# Altium schematic sheet D1_CORE_INTERFACE.SchDoc(D1_CORE_INTERFACE)
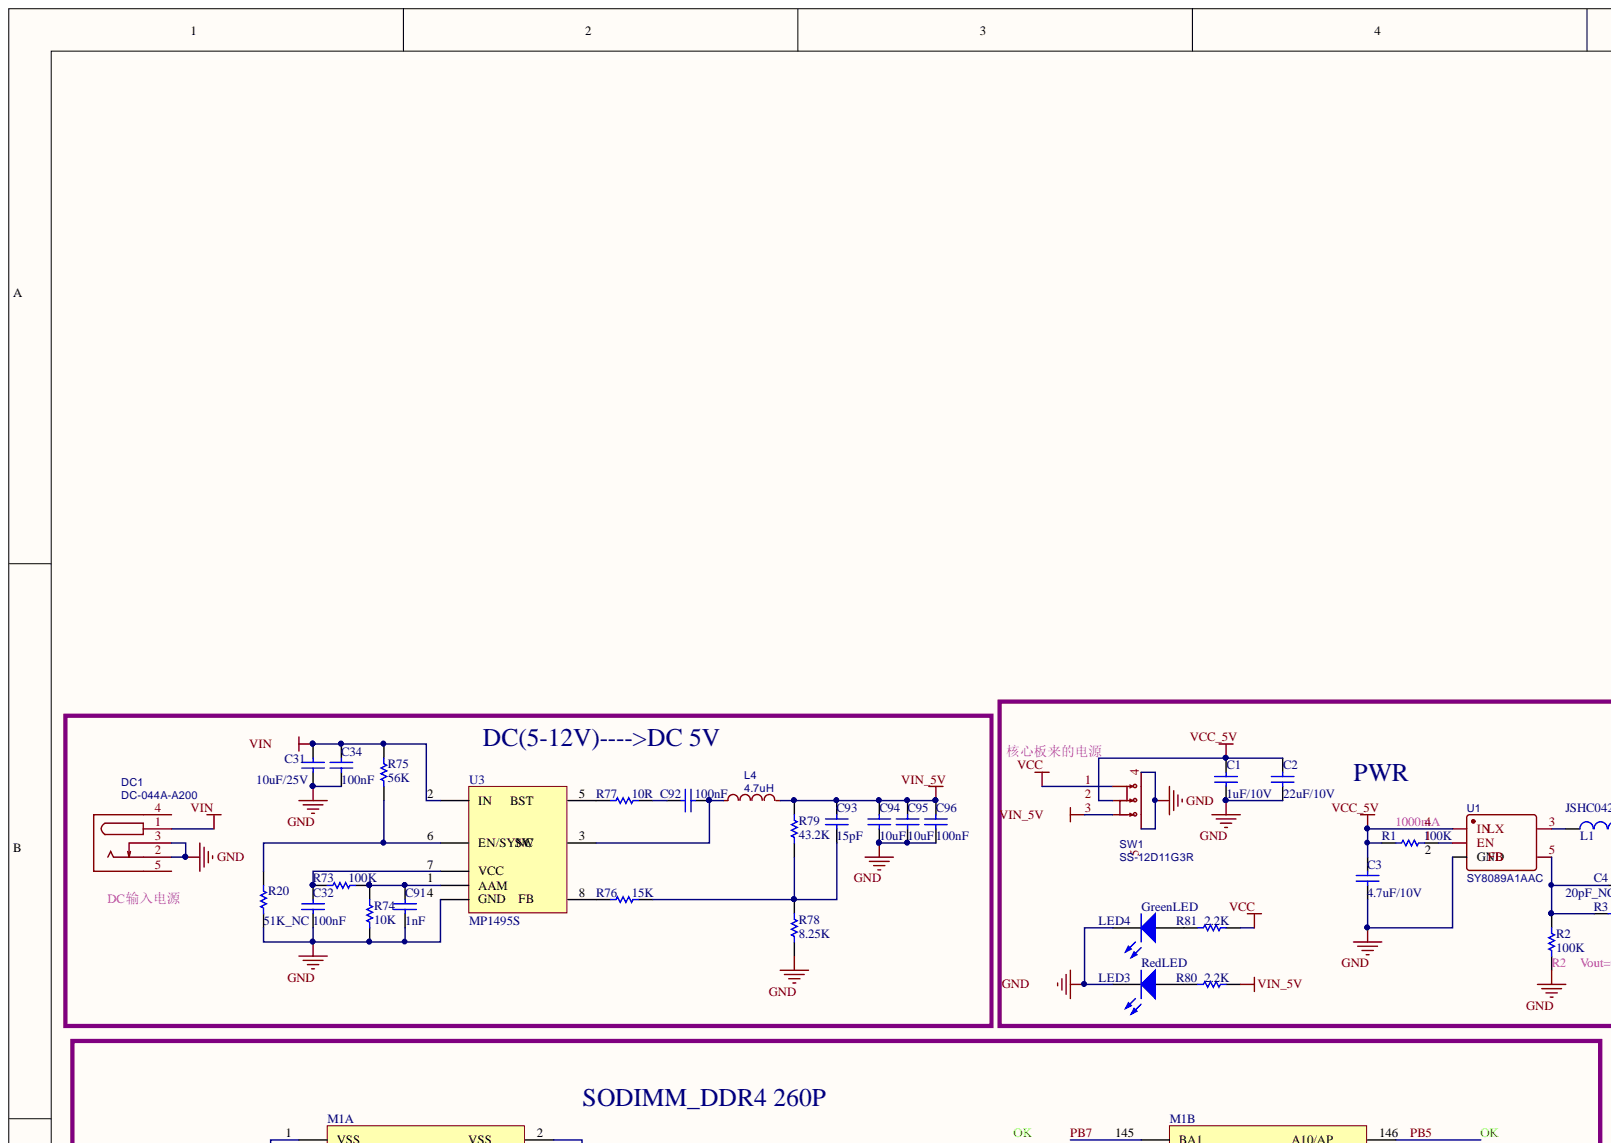
[diagram p0: D1_CORE_INTERFACE.SchDoc, top left]
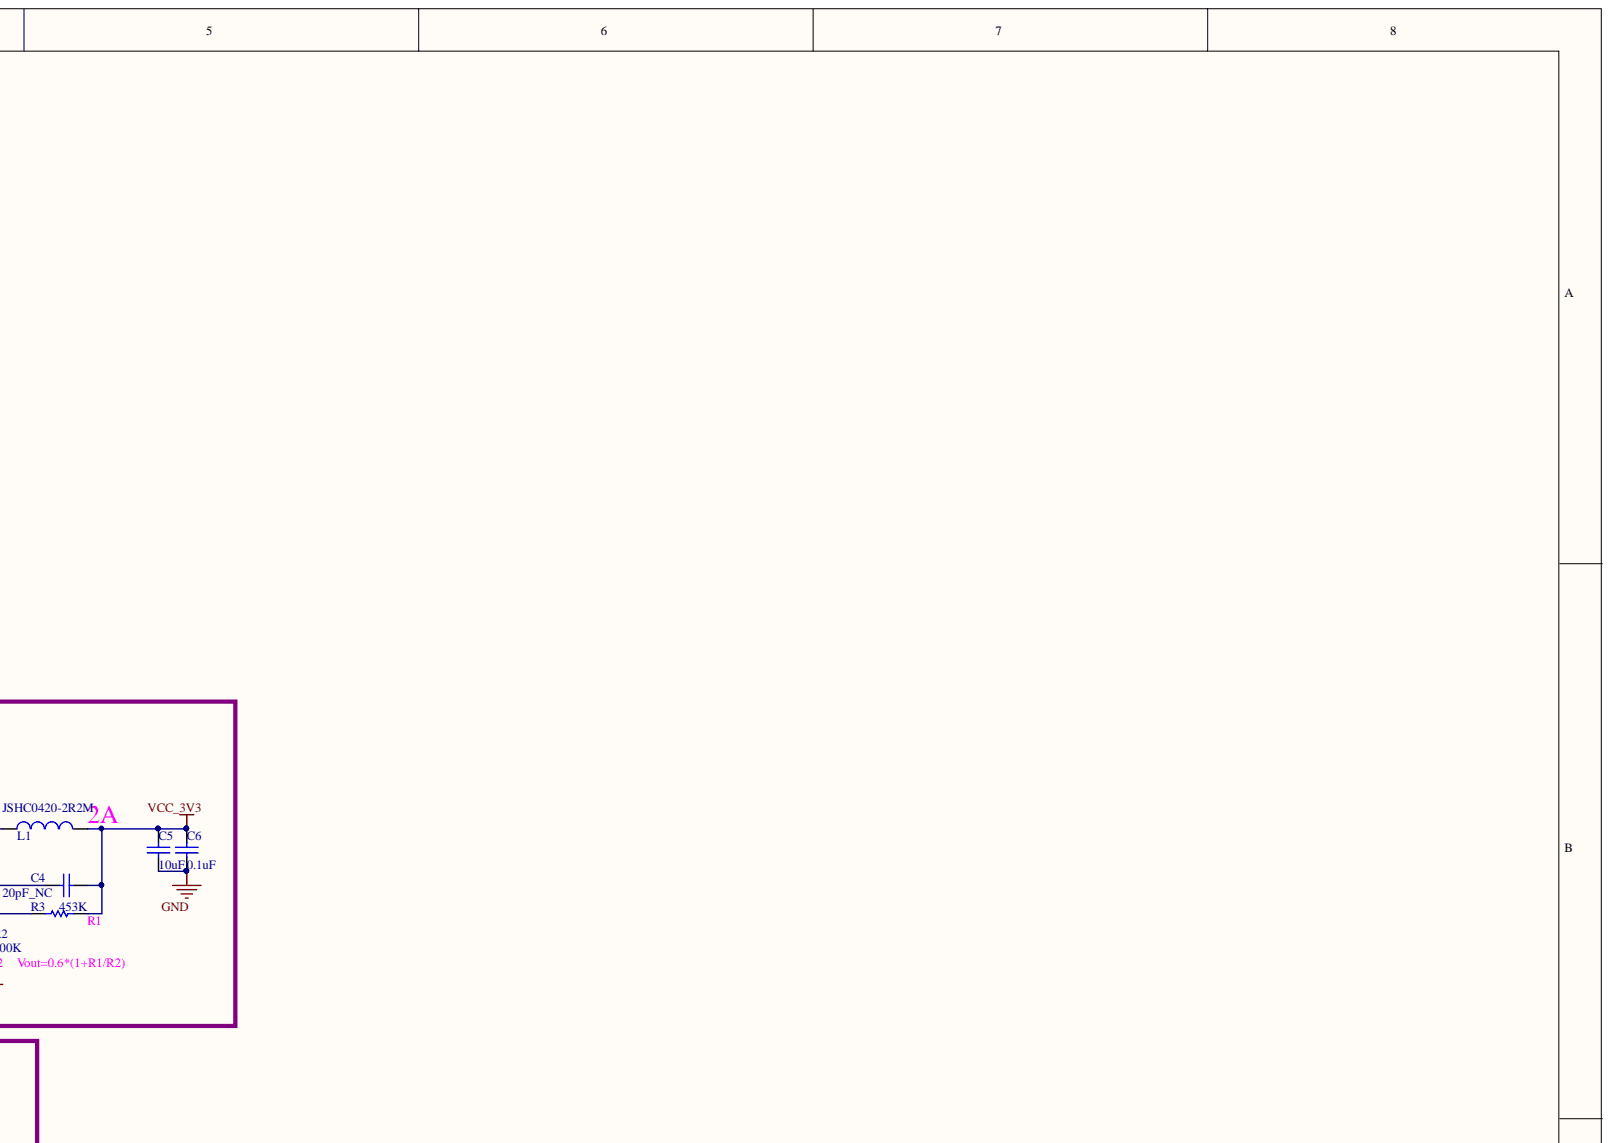
[diagram p1: D1_CORE_INTERFACE.SchDoc, top right]
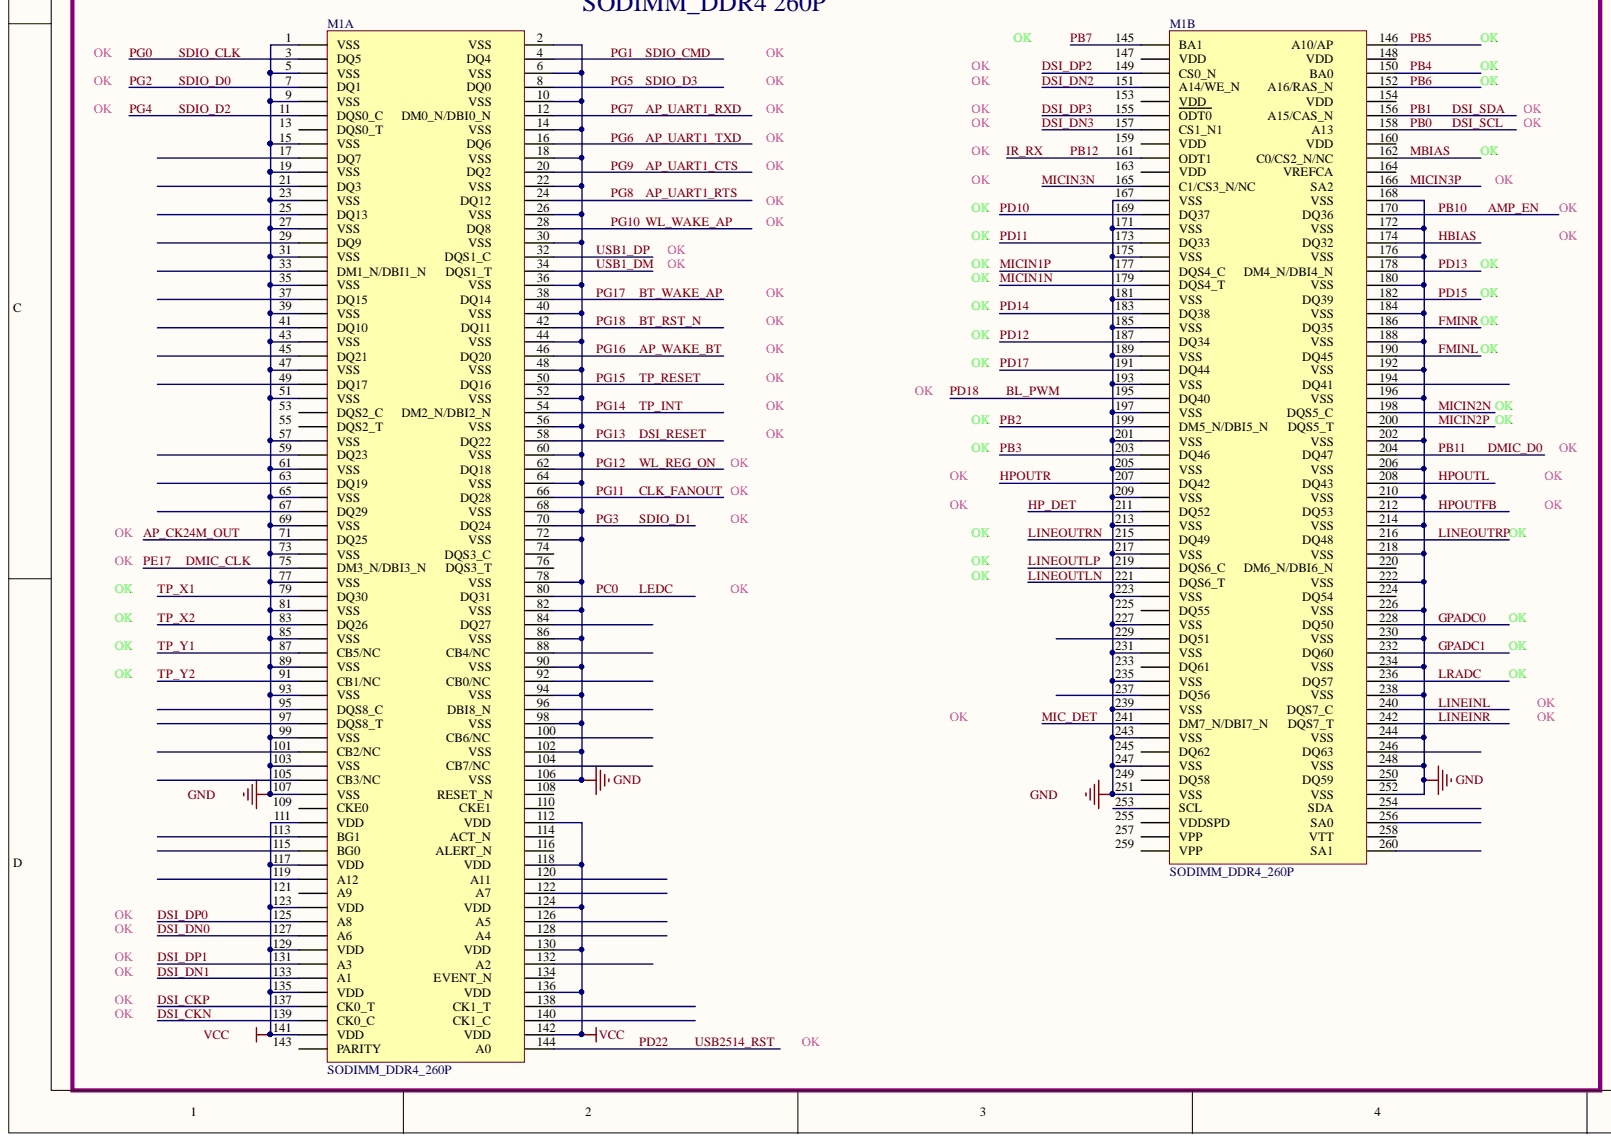
[diagram p2: D1_CORE_INTERFACE.SchDoc, bottom left]
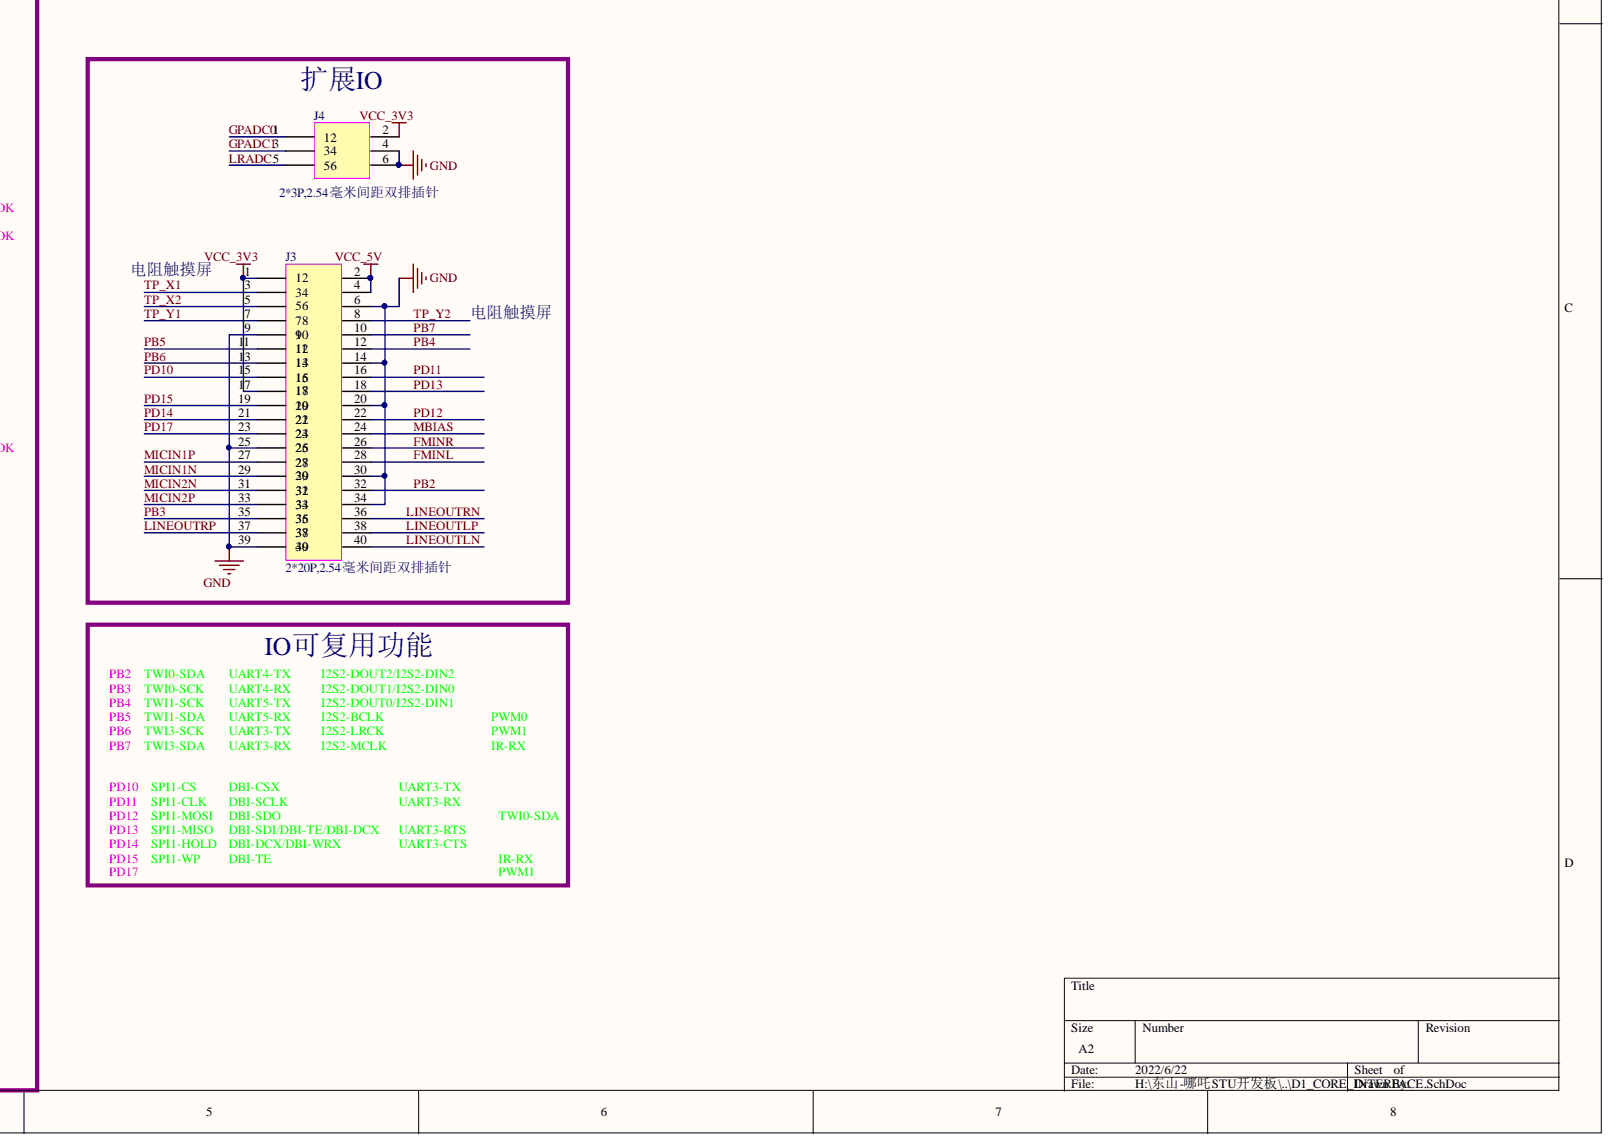
[diagram p3: D1_CORE_INTERFACE.SchDoc, bottom right]

## Parts
C1  1uF/10V  [0402]
C2  22uF/10V  [0603]
C3  4.7uF/10V  [0402]
C4  20pF_NC  [0402]
C5  10uF  [0402]
C6  0.1uF  [0402]
C31  10uF/25V  [0603]
C32  100nF  [0402]
C34  100nF  [0402]
C91  1nF  [0402]
C92  100nF  [0402]
C93  15pF  [0402]
C94  10uF  [0402]
C95  10uF  [0402]
C96  100nF  [0402]
DC1  DC-044A-A200  [DC-IN-TH_DC-044A-20A]
J3  2*20P,2.54毫米间距双排插针  [IDE2X20]
J4  2*3P,2.54毫米间距双排插针  [IDC6]
L1  JSHC0420-2R2M  [IND-SMD_L4.9-W4.5]
L4  4.7uH  [IND-SMD_L6.0-W6.0]
LED3  RedLED  [LED0402]
LED4  GreenLED  [LED0402]
M1  SODIMM_DDR4_260P  [CONN-SMD_D1_CORE_BOARD]  (parts A,B)
R1  100K  [0402]
R2  100K  [0402]
R3  453K  [0402]
R20  51K_NC  [0402]
R73  100K  [0402]
R74  10K  [0402]
R75  56K  [0402]
R76  15K  [0402]
R77  10R  [0402]
R78  8.25K  [0402]
R79  43.2K  [0402]
R80  2.2K  [0402]
R81  2.2K  [0402]
SW1  SS-12D11G3R  [SW-TH_SS-12D11L3]
U1  SY8089A1AAC  [SOT-23-5_L3.0-W1.7-P0.95-LS2.8-BL]
U3  MP1495S  [TSOT-23-8]

## Nets
GND: C1.1 C2.1 C3.1 C5.1 C6.1 C31.2 C32.2 C34.2 C91.2 C94.2 C95.2 C96.2 DC1.2 DC1.3 J3.6 J3.9 J3.14 J3.20 J3.25 J3.30 J3.34 J3.39 J4.4 J4.6 LED3.2 LED4.2 M1.1(VSS) M1.2 M1.5(VSS) M1.6 M1.9(VSS) M1.10 M1.14 M1.15(VSS) M1.18 M1.19(VSS) M1.22 M1.23(VSS) M1.26 M1.27(VSS) M1.30 M1.31(VSS) M1.35(VSS) M1.36 M1.39(VSS) M1.40 M1.43(VSS) M1.44 M1.47(VSS) M1.48 M1.51(VSS) M1.52 M1.56 M1.57(VSS) M1.60 M1.61(VSS) M1.64 M1.65(VSS) M1.68 M1.69(VSS) M1.72 M1.73(VSS) M1.77(VSS) M1.78 M1.81(VSS) M1.82 M1.85(VSS) M1.86 M1.89(VSS) M1.90 M1.93(VSS) M1.94 M1.98 M1.99(VSS) M1.102 M1.103(VSS) M1.106 M1.107(VSS) M1.167(VSS) M1.168 M1.171(VSS) M1.172 M1.175(VSS) M1.176 M1.180 M1.181(VSS) M1.184 M1.185(VSS) M1.188 M1.189(VSS) M1.192 M1.193(VSS) M1.196 M1.197(VSS) M1.201(VSS) M1.202 M1.205(VSS) M1.206 M1.209(VSS) M1.210 M1.213(VSS) M1.214 M1.217(VSS) M1.218 M1.222 M1.223(VSS) M1.226 M1.227(VSS) M1.230 M1.231(VSS) M1.234 M1.235(VSS) M1.238 M1.239(VSS) M1.243(VSS) M1.244 M1.247(VSS) M1.248 M1.251(VSS) M1.252 R2.2 R20.2 R74.2 R78.2 SW1.4 SW1.5 U1.2(GND) U3.4(GND)
VCC: M1.111(VDD) M1.112 M1.117(VDD) M1.118 M1.123(VDD) M1.124 M1.129(VDD) M1.130 M1.135(VDD) M1.136 M1.141(VDD) M1.142 R81.2 SW1.1
VCC_3V3: C4.2 C5.2 C6.2 J3.1(1_2) J3.17 J4.2 L1.2 R3.1
VCC_5V: C1.2 C2.2 C3.2 J3.2 J3.4 R1.2 SW1.2 U1.4(IN)
VIN: C31.1 C34.1 DC1.1 R75.1 U3.2(IN)
VIN_5V: C93.1 C94.1 C95.1 C96.1 L4.2 R79.1 R80.2 SW1.3
AMP_EN: M1.170
AP_CK24M_OUT: M1.71(DQ25)
AP_UART1_CTS: M1.20
AP_UART1_RTS: M1.24
AP_UART1_RXD: M1.12
AP_UART1_TXD: M1.16
AP_WAKE_BT: M1.46
BL_PWM: M1.195(DQ40)
BT_RST_N: M1.42
BT_WAKE_AP: M1.38
CLK_FANOUT: M1.66
DMIC_CLK: M1.75(DM3_N/DBI3_N)
DMIC_D0: M1.204
DSI_CKN: M1.139(CK0_C)
DSI_CKP: M1.137(CK0_T)
DSI_DN0: M1.127(A6)
DSI_DN1: M1.133(A1)
DSI_DN2: M1.151(A14/WE_N)
DSI_DN3: M1.157(CS1_N1)
DSI_DP0: M1.125(A8)
DSI_DP1: M1.131(A3)
DSI_DP2: M1.149(CS0_N)
DSI_DP3: M1.155(ODT0)
DSI_RESET: M1.58
DSI_SCL: M1.158
DSI_SDA: M1.156
FMINL: J3.28 M1.190
FMINR: J3.26 M1.186
GPADC0: J4.1(1_2) M1.228
GPADC1: J4.3(3_4) M1.232
HBIAS: M1.174
HP_DET: M1.211(DQ52)
HPOUTFB: M1.212
HPOUTL: M1.208
HPOUTR: M1.207(DQ42)
IR_RX: M1.161(ODT1)
LEDC: M1.80
LINEINL: M1.240
LINEINR: M1.242
LINEOUTLN: J3.40 M1.221(DQS6_T)
LINEOUTLP: J3.38 M1.219(DQS6_C)
LINEOUTRN: J3.36 M1.215(DQ49)
LINEOUTRP: J3.37 M1.216
LRADC: J4.5(5_6) M1.236
MBIAS: J3.24 M1.162
MIC_DET: M1.241(DM7_N/DBI7_N)
MICIN1N: J3.29 M1.179(DQS4_T)
MICIN1P: J3.27 M1.177(DQS4_C)
MICIN2N: J3.31 M1.198
MICIN2P: J3.33 M1.200
MICIN3N: M1.165(C1/CS3_N/NC)
MICIN3P: M1.166
PB2: J3.32 M1.199(DM5_N/DBI5_N)
PB3: J3.35 M1.203(DQ46)
PB4: J3.12 M1.150
PB5: J3.11 M1.146
PB6: J3.13 M1.152
PB7: J3.10 M1.145(BA1)
PD10: J3.15 M1.169(DQ37)
PD11: J3.16 M1.173(DQ33)
PD12: J3.22 M1.187(DQ34)
PD13: J3.18 M1.178
PD14: J3.21 M1.183(DQ38)
PD15: J3.19 M1.182
PD17: J3.23 M1.191(DQ44)
PD22: M1.144
PG0: M1.3(DQ5)
PG1: M1.4
PG2: M1.7(DQ1)
PG3: M1.70
PG4: M1.11(DQS0_C)
PG5: M1.8
PG10: M1.28
PG12: M1.62
PG14: M1.54
PG15: M1.50
TP_X1: J3.3(3_4) M1.79(DQ30)
TP_X2: J3.5(5_6) M1.83(DQ26)
TP_Y1: J3.7(7_8) M1.87(CB5/NC)
TP_Y2: J3.8 M1.91(CB1/NC)
USB1_DM: M1.34
USB1_DP: M1.32
NetC4_1: C4.1 R2.1 R3.2 U1.5
NetC32_1: C32.1 R73.2 U3.7(VCC)
NetC91_1: C91.1 R73.1 R74.1 U3.1(AAM)
NetC92_1: C92.1 R77.1
NetC92_2: C92.2 L4.1 U3.3
NetC93_2: C93.2 R76.1 R78.1 R79.2
NetL1_1: L1.1 U1.3
NetLED3_1: LED3.1 R80.1
NetLED4_1: LED4.1 R81.1
NetR1_1: R1.1 U1.1(EN)
NetR20_1: R20.1 R75.2 U3.6(EN/SYNC)
NetR76_2: R76.2 U3.8
NetR77_2: R77.2 U3.5

Not connected: DC1 4 5; M1 13(DQS0_T) 17(DQ7) 21(DQ3) 25(DQ13) 29(DQ9) 33(DM1_N/DBI1_N) 37(DQ15) 41(DQ10) 45(DQ21) 49(DQ17) 53(DQS2_C) 55(DQS2_T) 59(DQ23) 63(DQ19) 67(DQ29) 74 76 84 88 92 95(DQS8_C) 96 97(DQS8_T) 100 101(CB2/NC) 104 105(CB3/NC) 108 109(CKE0) 110 113(BG1) 114 115(BG0) 116 119(A12) 120 121(A9) 122 126 128 132 134 138 140 143(PARITY) 147(VDD) 148 153(VDD) 154 159(VDD) 160 163(VDD) 164 194 220 224 225(DQ55) 229(DQ51) 233(DQ61) 237(DQ56) 245(DQ62) 246 249(DQ58) 250 253(SCL) 254 255(VDDSPD) 256 257(VPP) 258 259(VPP) 260

## Library data of the parts
C1-C6 C31 C32 C34 C91-C96 = Cap: Capacitor; PartNumber: CAP100NF; Component Kind: Standard; LatestRevisionDate: 17-Jul-2002; LatestRevisionNote: Re-released for DXP Platform.; Library Name: Miscellaneous Devices.IntLib; PackageReference: 0402; Published: 8-Jun-2000; Signal Integrity: Cap; Simulation: CAP; Publisher: Altium Limited
  C1: Value: 1uF/10V
  C2: Value: 22uF/10V
  C3: Value: 4.7uF/10V
  C4: Value: 20pF_NC
  C5 C94 C95: Value: 10uF
  C6: Value: 0.1uF
  C31: Value: 10uF/25V
  C32 C34 C92 C96: Value: 100nF
  C91: Value: 1nF
  C93: Value: 15pF
DC1 = DC-044A-A200: Contributor: lcsc; BOM_Manufacturer Part: DC-044A-A200; BOM_Supplier: LCSC; BOM_Supplier Part: C720575; Component Kind: Standard; spicePre: D; spiceSymbolName: DC-044A-A200
J3 = IDC40: Component Kind: Standard; Library Name: HM2.SchLib; Value: 2*20P,2.54毫米间距双排插针
J4 = IDC6: Component Kind: Standard; Library Name: HM2.SchLib; Value: 2*3P,2.54毫米间距双排插针
L1 = Inductor: PartNumber: IND10UH; Code_IEC: 1005; Code_JEITA: 0402; Component Kind: Standard; Description: Inductor; JLC NO: C386778; LatestRevisionDate: 29-May-2009; LatestRevisionNote: IPC-7351 Footprint Added.; Library Name: Miscellaneous Devices.IntLib; PackageDescription: Chip Inductor; PackageReference: 0402-A; Published: 8-Jun-2000; Signal Integrity: Inductor; Simulation: INDUCTOR; Value: JSHC0420-2R2M; Publisher: Altium Limited
L4 = 4.7uH: BOM_Manufacturer: Sunltech Tech; BOM_Manufacturer Part: SLW6028S4R7MST; Contributor: LCSC; nameAlias: Inductance; BOM_JLCPCB Part Class: Extended Part; BOM_Supplier: LCSC; BOM_Supplier Part: C206281; Component Kind: Standard; spicePre: L; spiceSymbolName: SLW6028S4R7MST
LED3 LED4 = LED2: Typical RED, GREEN, YELLOW, AMBER GaAs LED; Component Kind: Standard; LatestRevisionDate: 17-Jul-2002; LatestRevisionNote: Re-released for DXP Platform.; Library Name: Miscellaneous Devices.IntLib; PackageDescription: SM LED; 2 Flat Leads; PackageReference: 3.2X1.6X1.1; PackageVersion: Feb-2002; Published: 8-Jun-2000; Simulation: LED2; Publisher: Altium Limited
  LED3: Value: RedLED
  LED4: Value: GreenLED
R1-R3 R80 R81 = Res1: Resistor; PartNumber: RES2.2K; Component Kind: Standard; LatestRevisionDate: 17-Jul-2002; LatestRevisionNote: Re-released for DXP Platform.; Library Name: Miscellaneous Devices.IntLib; PackageReference: 0402; Published: 8-Jun-2000; Signal Integrity: Res; Simulation: RESISTOR; Publisher: Altium Limited
  R1 R2: Value: 100K
  R3: Value: 453K
  R80 R81: Value: 2.2K
R20 R73-R79 = Res1: Resistor; PartNumber: RES22R; Component Kind: Standard; LatestRevisionDate: 17-Jul-2002; LatestRevisionNote: Re-released for DXP Platform.; Library Name: Miscellaneous Devices.IntLib; PackageReference: 0402; Published: 8-Jun-2000; Signal Integrity: Res; Simulation: RESISTOR; Publisher: Altium Limited
  R20: Value: 51K_NC
  R73: Value: 100K
  R74: Value: 10K
  R75: Value: 56K
  R76: Value: 15K
  R77: Value: 10R
  R78: Value: 8.25K
  R79: Value: 43.2K
SW1 = SS-12D11G3R: BOM_Manufacturer: XKB Connectivity; Contributor: LCSC; BOM_JLCPCB Part Class: Extended Part; BOM_Manufacturer Part: SS-12D11G3R; BOM_Supplier: LCSC; BOM_Supplier Part: C843362; Component Kind: Standard; spicePre: S; spiceSymbolName: SS-12D11G3R
U1 = SY8089A1AAC: BOM_Manufacturer: Silergy Corp; Contributor: LCSC; BOM_JLCPCB Part Class: Extended Part; BOM_Manufacturer Part: SY8089A1AAC; BOM_Supplier: LCSC; BOM_Supplier Part: C479074; Component Kind: Standard; Library Name: HM2.SchLib; spicePre: U; spiceSymbolName: SY8089A1AAC
M1 = SODIMM_DDR4_260P: Component Kind: Standard; Library Name: HM2.SchLib
U3 = MP1495S: Component Kind: Standard; Library Name: HM2.SchLib; Value: MP1495S
